# Altium schematic sheet cwh_glitchcrowbar.SchDoc
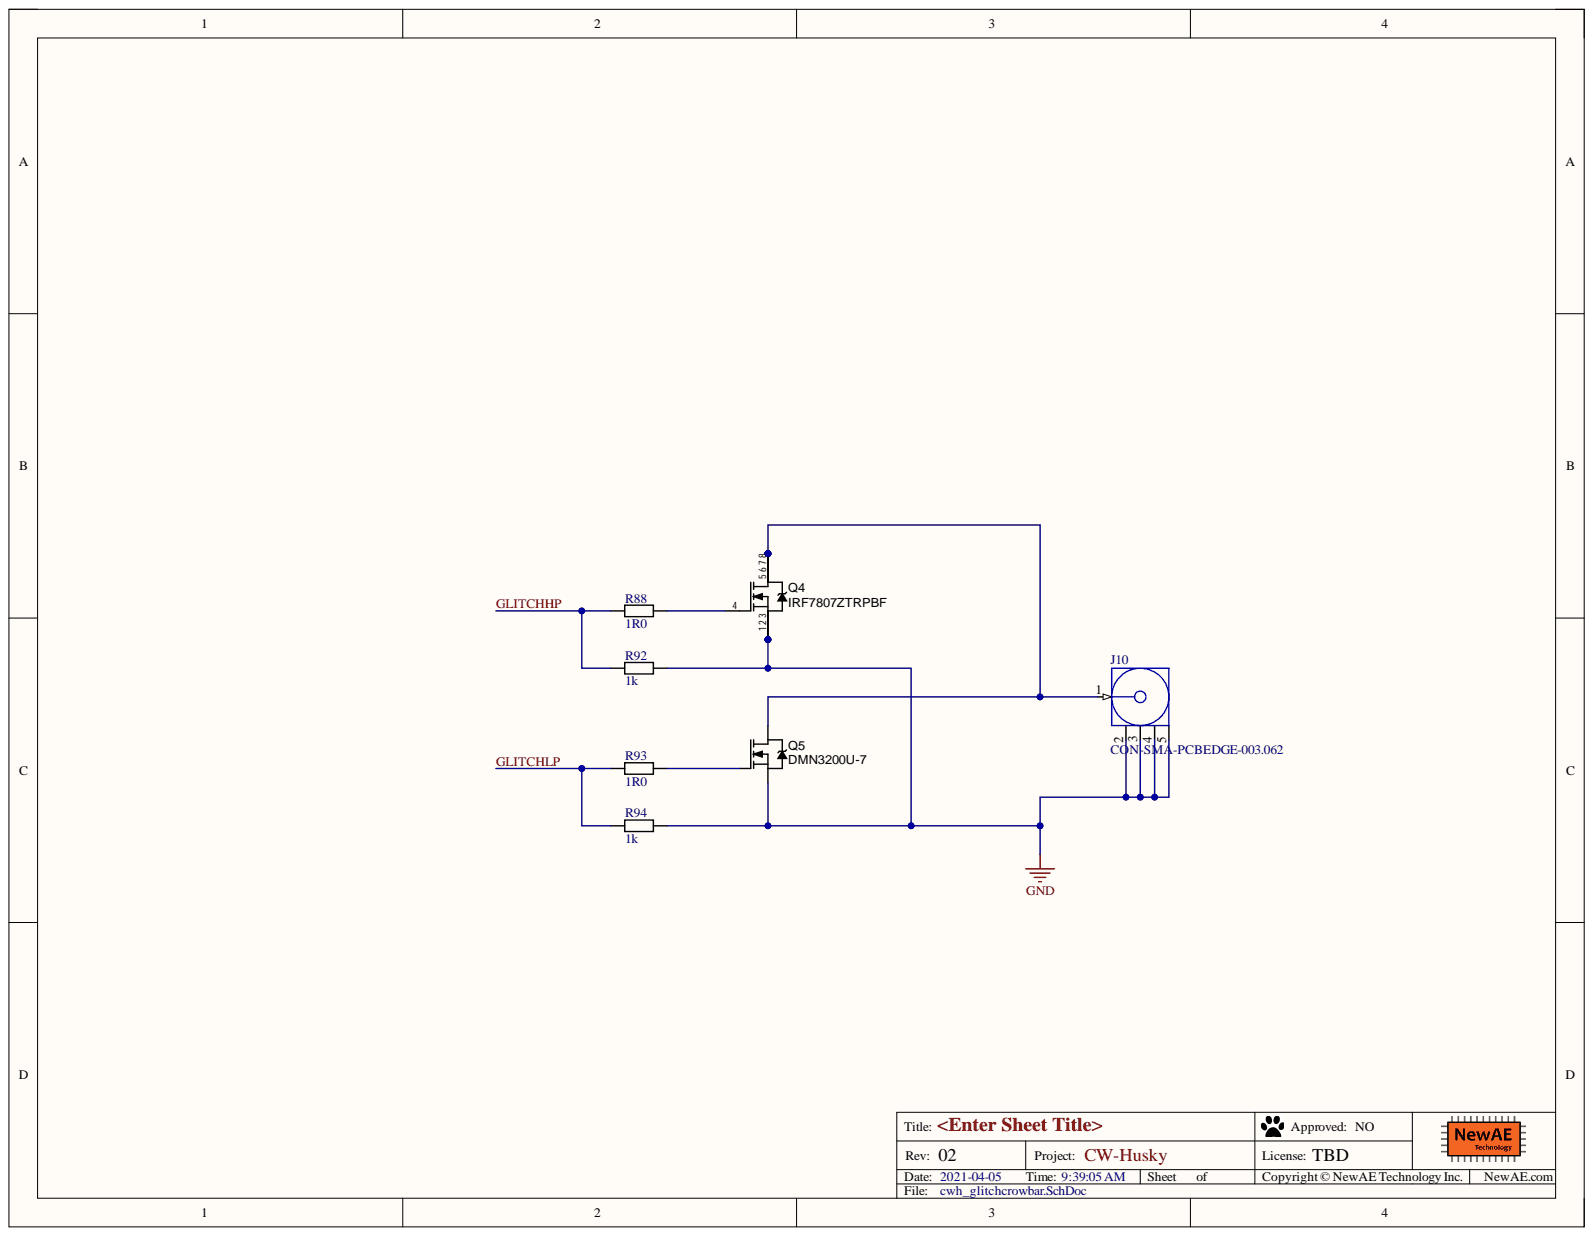
[diagram p0: cwh_glitchcrowbar.SchDoc]

## Parts
J10  CON-SMA-PCBEDGE-003.062  [CON-SMAM-PCB-EDGE062]
Q4  IRF7807ZTRPBF  [8-SOIC]
Q5  DMN3200U-7  [SOT23]
R88  1R0  [0603]
R92  1k  [0402]
R93  1R0  [0603]
R94  1k  [0402]

## Nets
GND: J10.2 J10.3 J10.4 J10.5 Q4.1 Q4.2 Q4.3 Q5.2 R92.2 R94.2
GLITCHHP: R88.1 R92.1
GLITCHLP: R93.1 R94.1
NetJ10_1: J10.1 Q4.5 Q4.6 Q4.7 Q4.8 Q5.3
NetQ4_4: Q4.4 R88.2
NetQ5_1: Q5.1 R93.2

## Library data of the parts
J10 = CON-SMA-PCBEDGE-003.062: SMA Female Edge Mount Connector for 0.062" Thick Board; Database Table Name: main; Component Group: Connector; Component Kind: Standard; Component Type: Standard; ComponentLink1Description: Manufacturer URL; ComponentLink1URL: http://www.linxtechnologies.com/; ComponentLink2Description: Datasheet; ComponentLink2URL: http://www.connectorcity.com/diagrams/SMA/CONSMA003.062.pdf; Library Name: NewAE_AltiumLib.DBLib; Part Number: CON-SMA-PCBEDGE-003.062; Pin Count: 5
Q4 = IRF7807ZTRPBF: MOSFET, N-Channel, 8-SOIC, Logic Level; Manufacture 1: International Rectifier; Database Table Name: main; Component Group: MOSFET; Component Kind: Standard; Component Type: Standard; Library Name: NewAE_AltiumLib.DBLib; Manufacture Part Number 1: IRF7807ZTRPBF; Mounting Technology: SMT; Part Number: IRF7807ZTRPBF; Pin Count: 8; Supplier 1: Digi-Key; Supplier Part Number 1: IRF7807ZPBFCT-ND
Q5 = DMN3200U-7: MOSFET N-CH 30V 2.2A SOT23-3; Manufacture 1: Diodes Inc.; Database Table Name: main; PP Material Stack: 0; Rated Power: 3A; Component Group: MOSFET; Component Kind: Standard; Component Type: Standard; Library Name: NewAE_AltiumLib.DBLib; Manufacture Part Number 1: DMN3200U-7; Mounting Technology: SMT; Part Number: DMN3200U-7; Pin Count: 3; PP Rotation: 0; Rated Voltage: 30V; RoHS: Yes; Supplier 1: Digi-Key; Supplier Part Number 1: DMN3200U-7DICT-ND
R88 R93 = RCHIP-1R0-0603: 1R0 res, 0603, 1%, 1/10W; Manufacture 1: Yageo; Manufacture Part Number 1: RC0603FR-071RL; Case-Metric: 1608; Component Group: Resistor; Database Table Name: main; Rated Power: 0.1W; Rated Voltage: 75VDC; Case-EIA: 0603; Component Kind: Standard; Component Type: Standard; Library Name: NewAE_AltiumLib.DBLib; Mounting Technology: SMT; Part Number: RCHIP-1R0-0603; Pin Count: 2; Supplier 1: Digi-Key; Supplier Part Number 1: 311-1.00HRCT-ND; Temperature Range: -55C to 155C; Tolerance: 1%; Value: 1R0
R92 R94 = RCHIP-1K-0402: 1k res, 0402, 1%, 1/16W; Manufacture 1: Yageo; Manufacture Part Number 1: RC0402FR-071KL; Case-Metric: 1005; Component Group: Resistor; Database Table Name: main; Rated Power: 0.063W; Rated Voltage: 75VDC; Case-EIA: 0402; Component Kind: Standard; Component Type: Standard; Library Name: NewAE_AltiumLib.DBLib; Mounting Technology: SMT; Part Number: RCHIP-1K-0402; Pin Count: 2; Supplier 1: Digi-Key; Supplier Part Number 1: 311-1.00KLRCT-ND; Temperature Range: -55C to 155C; Tolerance: 1%; Value: 1k
